# Altium schematic sheet 6.BMP388.SchDoc(6.BMP388)
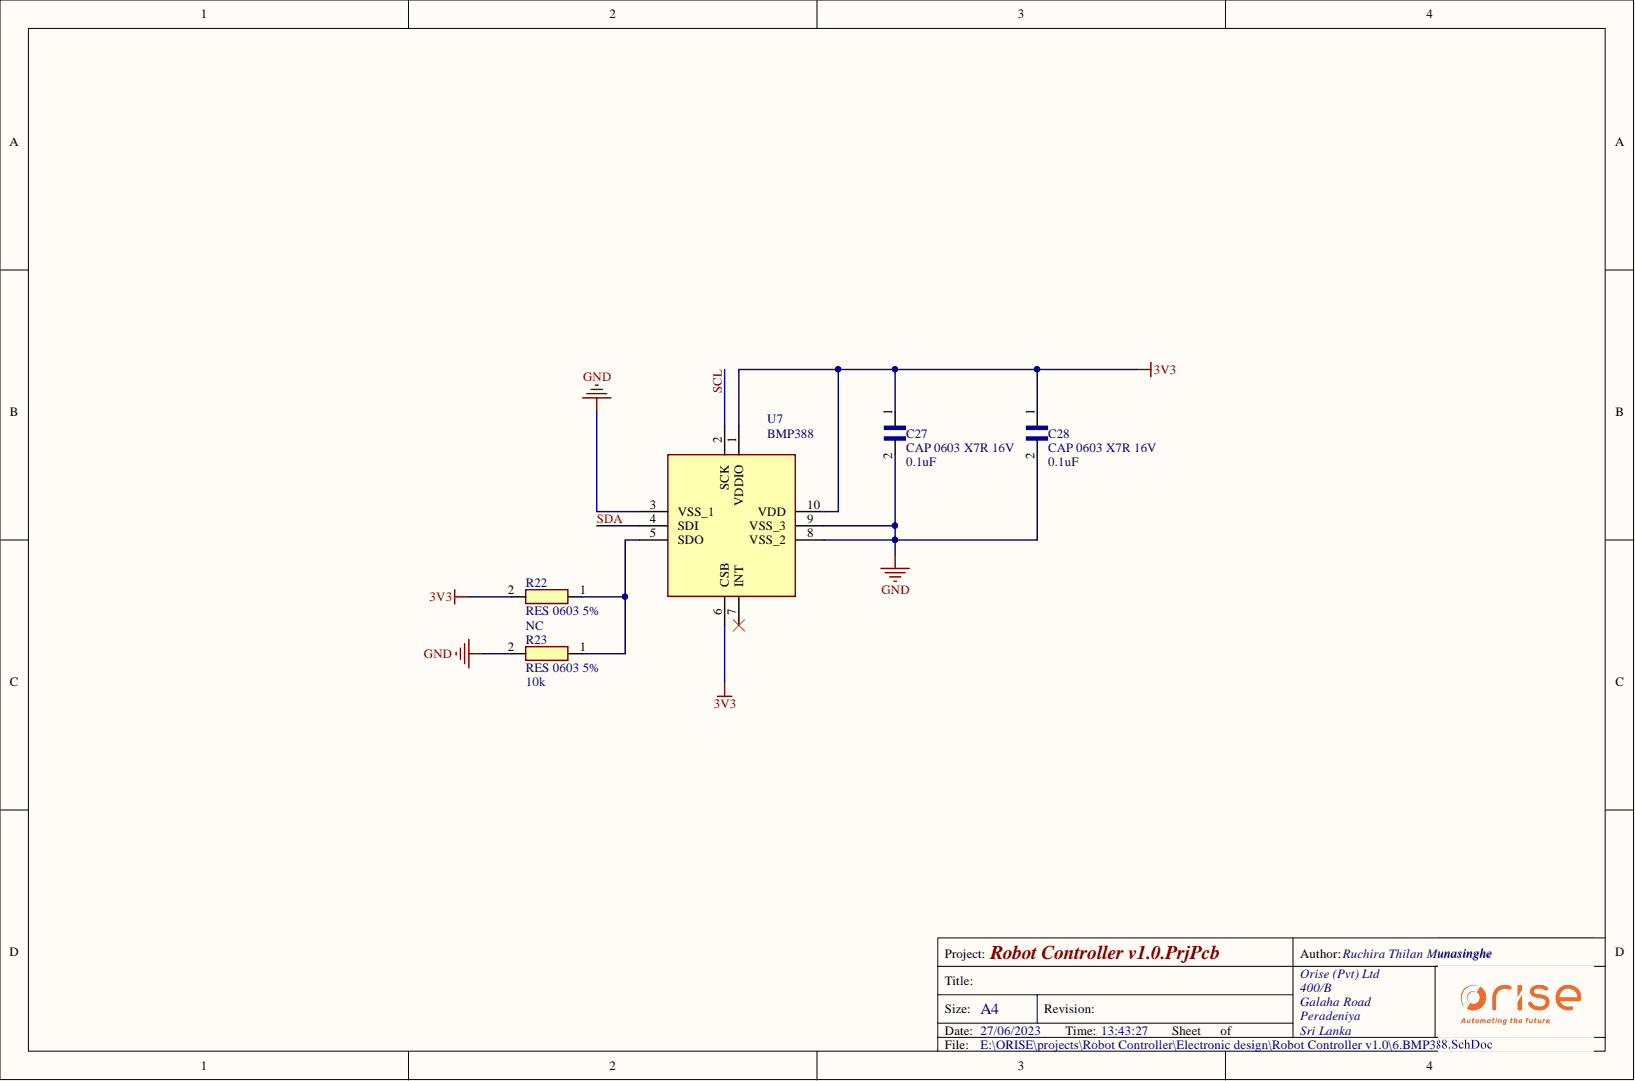
[diagram p0: 6.BMP388.SchDoc]

## Parts
C27  CAP 0603 X7R 16V  value 0.1uF  [CAP 0603]
C28  CAP 0603 X7R 16V  value 0.1uF  [CAP 0603]
R22  RES 0603 5%  value NC  [RES 0603 NC]
R23  RES 0603 5%  value 10k  [RES 0603]
U7  BMP388  [BMP388]

## Nets
3V3: C27.1 C28.1 R22.2 U7.1(VDDIO) U7.6(CSB) U7.10(VDD)
GND: C27.2 C28.2 R23.2 U7.3(VSS_1) U7.8(VSS_2) U7.9(VSS_3)
SCL: U7.2(SCK)
SDA: U7.4(SDI)
NetR22_1: R22.1 R23.1 U7.5(SDO)

Not connected: U7 7(INT)

## Library data of the parts
R22 = RES 0603 5% NC: value: NC; Library Name: Robot Controller v1.0.SchLib; Description: RES 0603 5%; Component Kind: Standard
U7 = BMP388: Integrated Circuit; Manufacturer_Name: BOSCH; Mouser Price/Stock: https://www.mouser.co.uk/ProductDetail/Bosch-Sensortec/BMP388?qs=rrS6PyfT74eIcD5Rv1Kf7A%3D%3D; Mouser Part Number: 262-BMP388; Manufacturer_Part_Number: BMP388; Library Name: Robot Controller v1.0.SchLib; Height: 0mm; Datasheet Link: https://datasheet.datasheetarchive.com/originals/distributors/DKDS-39/10253.pdf; Component Kind: Standard; Arrow Price/Stock: https://www.arrow.com/en/products/bmp388/bosch?region=nac; Arrow Part Number: BMP388
C27 C28 = CAP 0603 X7R 16V: Library Name: Robot Controller v1.0.SchLib; Description: CAP 0603 X7R 16V; Component Kind: Standard
R23 = RES 0603 5%: Library Name: Robot Controller v1.0.SchLib; Description: RES 0603 5%; Component Kind: Standard
